# Altium schematic sheet Sheet2.SchDoc
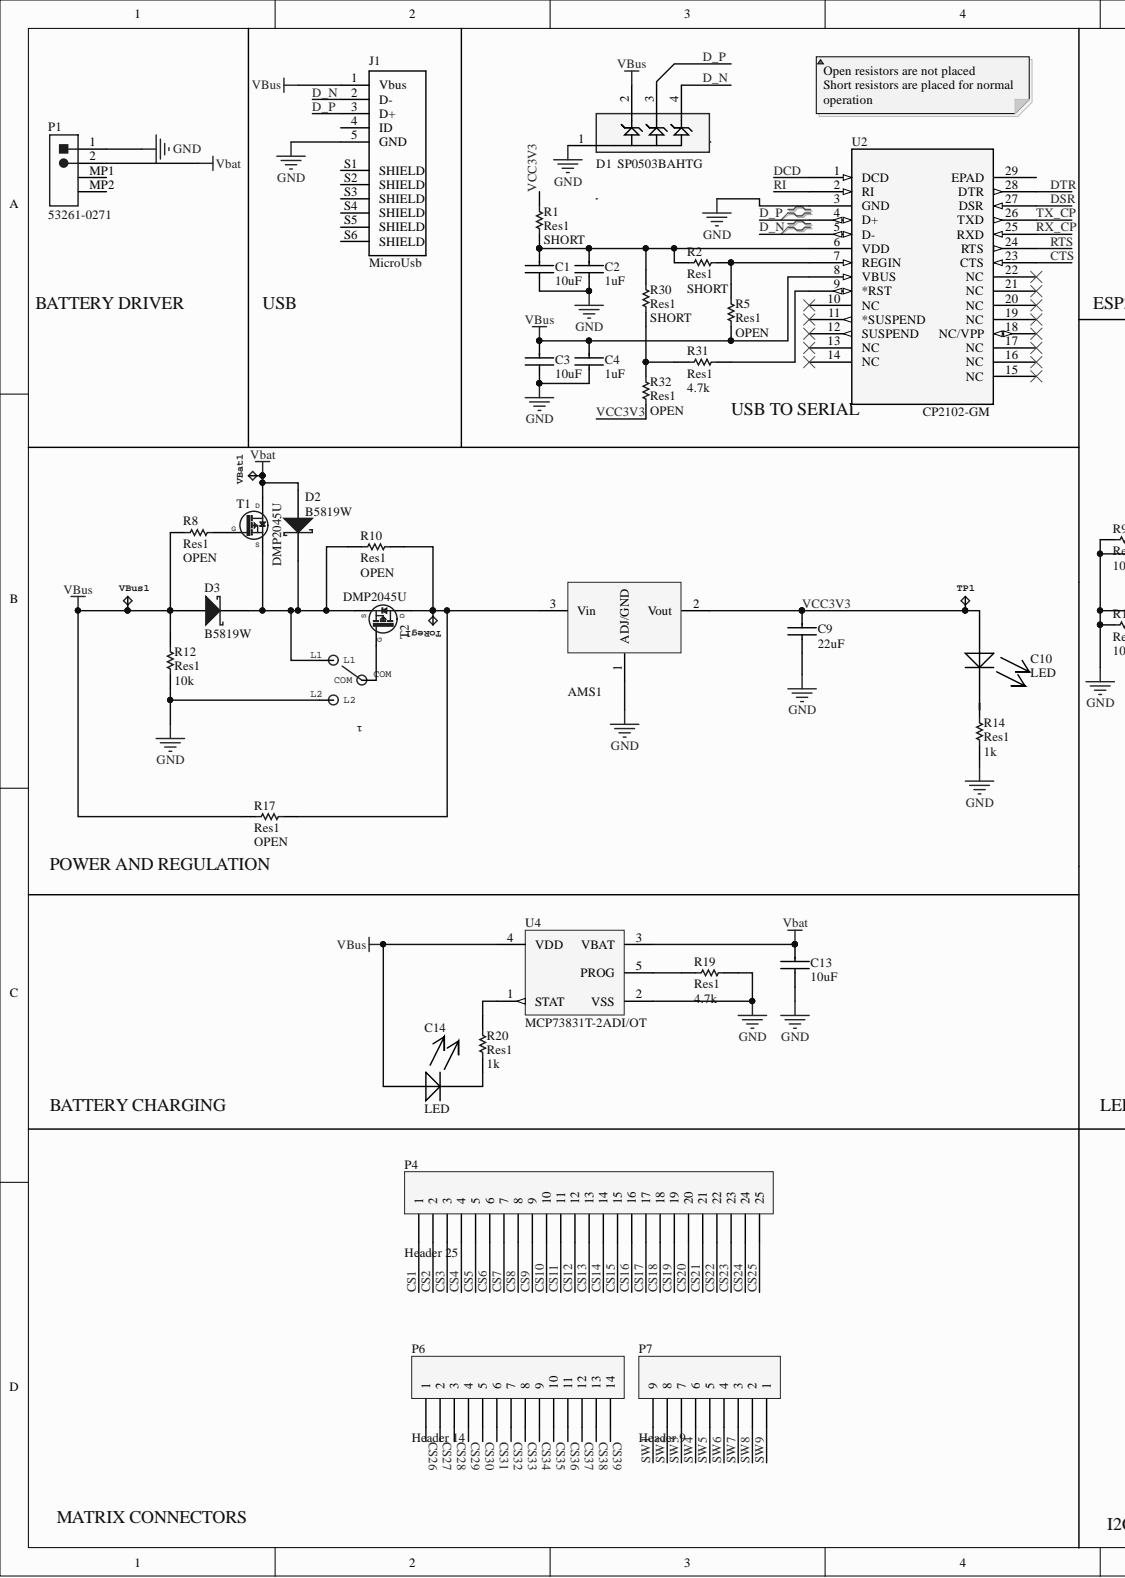
[diagram p0: Sheet2.SchDoc, left]
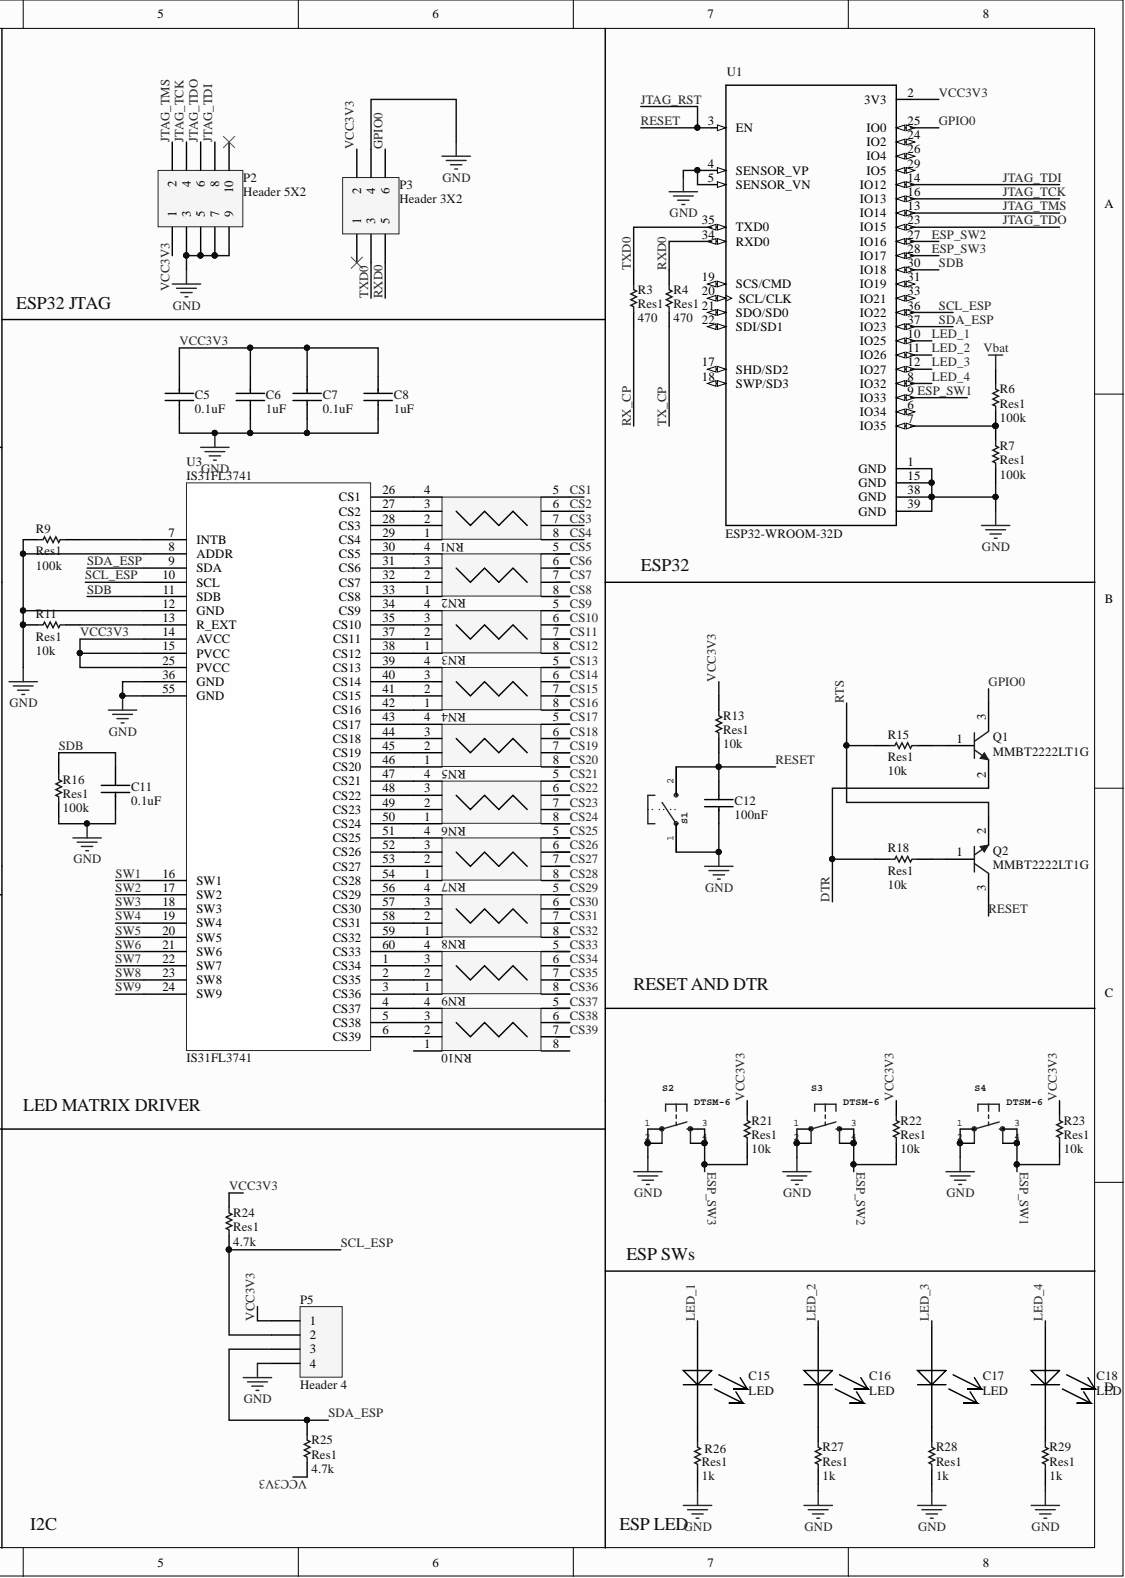
[diagram p1: Sheet2.SchDoc, right]

## Parts
1  Footprint of the VIMEX MSK-12C02 SPDT SMD Switch Created by mzst on 17-Jan-2016  [MSK-12C02]  (value not drawn)
AMS1  AMS1117  [SOT-223-3]  (value not drawn)
C1  10uF  [C 0603 / 1608]
C2  1uF  [C 0603 / 1608]
C3  10uF  [C 0603 / 1608]
C4  1uF  [C 0603 / 1608]
C5  0.1uF  [C 0603 / 1608]
C6  1uF  [C 0603 / 1608]
C7  0.1uF  [C 0603 / 1608]
C8  1uF  [C 0603 / 1608]
C9  22uF  [C 0603 / 1608]
C10  LED  [LED 0603(2)]
C11  0.1uF  [C 0603 / 1608]
C12  100nF  [C 0603 / 1608]
C13  10uF  [C 0603 / 1608]
C14  LED  [LED 0603(2)]
C15  LED  [LED 0603(2)]
C16  LED  [LED 0603(2)]
C17  LED  [LED 0603(2)]
C18  LED  [LED 0603(2)]
D1  Part Number: SP0503BAHTG  [Littelfuse_SP0503BAHTG_0]  (hidden comment: Diode_Littelfuse_SP0503BAHTG_eec)
D2  B5819W  [MCC-SOD123_V]
D3  B5819W  [MCC-SOD123_V]
J1  MicroUsb  [USB-MicroB]
P1  53261-0271  [MOLX-53261-0271_V]
P2  Header 5X2  [5x2_SidePCB]
P3  Header 3X2  [3x2_SidePCB]
P4  Header 25  [HDR1X25]
P5  Header 4  [HDR1X4]
P6  Header 14  [HDR1X14]
P7  Header 9  [HDR1X9]
Q1  MMBT2222LT1G  [ONSC-SOT-23-3-318-08_M]
Q2  MMBT2222LT1G  [ONSC-SOT-23-3-318-08_M]
R1  Res1  value SHORT  [C 0603 / 1608]
R2  Res1  value SHORT  [C 0603 / 1608]
R3  Res1  value 470  [C 0603 / 1608]
R4  Res1  value 470  [C 0603 / 1608]
R5  Res1  value OPEN  [C 0603 / 1608]
R6  Res1  value 100k  [C 0603 / 1608]
R7  Res1  value 100k  [C 0603 / 1608]
R8  Res1  value OPEN  [C 0603 / 1608]
R9  Res1  value 100k  [C 0603 / 1608]
R10  Res1  value OPEN  [C 0603 / 1608]
R11  Res1  value 10k  [C 0603 / 1608]
R12  Res1  value 10k  [C 0603 / 1608]
R13  Res1  value 10k  [C 0603 / 1608]
R14  Res1  value 1k  [C 0603 / 1608]
R15  Res1  value 10k  [C 0603 / 1608]
R16  Res1  value 100k  [C 0603 / 1608]
R17  Res1  value OPEN  [C 0603 / 1608]
R18  Res1  value 10k  [C 0603 / 1608]
R19  Res1  value 4.7k  [C 0603 / 1608]
R20  Res1  value 1k  [C 0603 / 1608]
R21  Res1  value 10k  [C 0603 / 1608]
R22  Res1  value 10k  [C 0603 / 1608]
R23  Res1  value 10k  [C 0603 / 1608]
R24  Res1  value 4.7k  [C 0603 / 1608]
R25  Res1  value 4.7k  [C 0603 / 1608]
R26  Res1  value 1k  [C 0603 / 1608]
R27  Res1  value 1k  [C 0603 / 1608]
R28  Res1  value 1k  [C 0603 / 1608]
R29  Res1  value 1k  [C 0603 / 1608]
R30  Res1  value SHORT  [C 0603 / 1608]
R31  Res1  value 4.7k  [C 0603 / 1608]
R32  Res1  value OPEN  [C 0603 / 1608]
RN1  742C083121JP  [RESARRAY(4x0603)]  (value not drawn)
RN2  742C083121JP  [RESARRAY(4x0603)]  (value not drawn)
RN3  742C083121JP  [RESARRAY(4x0603)]  (value not drawn)
RN4  742C083121JP  [RESARRAY(4x0603)]  (value not drawn)
RN5  742C083121JP  [RESARRAY(4x0603)]  (value not drawn)
RN6  742C083121JP  [RESARRAY(4x0603)]  (value not drawn)
RN7  742C083121JP  [RESARRAY(4x0603)]  (value not drawn)
RN8  742C083121JP  [RESARRAY(4x0603)]  (value not drawn)
RN9  742C083121JP  [RESARRAY(4x0603)]  (value not drawn)
RN10  742C083121JP  [RESARRAY(4x0603)]  (value not drawn)
S1  Switch  [PUSH_SMD_3x4]  (value not drawn)
S2  DTSM-6  [DTSM-6]
S3  DTSM-6  [DTSM-6]
S4  DTSM-6  [DTSM-6]
T1  DMP2045U  [SOT95P237X112-3N]
T2  DMP2045U  [SOT95P237X112-3N]
ToReg1  Test pad  [TP20R]  (value not drawn)
TP1  Test pad  [TP20R]  (value not drawn)
U1  ESP32-WROOM-32D  [MODULE_ESP32-WROOM-32D]
U2  CP2102-GM  [QFN28_5X5]
U3  IS31FL3741  [QFN40P700X700X80-61N]
U4  MCP73831T-2ADI/OT  [SOT-23-OT5_M]
VBat1  Test pad  [TP20R]  (value not drawn)
VBus1  Test pad  [TP20R]  (value not drawn)

## Nets
GND: 1.L2 AMS1.1 C1.1 C2.1 C3.1 C4.1 C5.1 C6.1 C7.1 C8.1 C9.1 C11.2 C12.2 C13.1 D1.1 J1.5(GND) P1.1 P2.3 P2.5 P2.7 P2.9 P3.4 P5.4 R7.1 R9.1 R11.1 R12.2 R14.2 R16.1 R19.1 R26.2 R27.2 R28.2 R29.2 S1.1 S2.1 S2.2 S3.1 S3.2 S4.1 S4.2 U1.1(GND) U1.4(SENSOR_VP) U1.5(SENSOR_VN) U1.15(GND) U1.38(GND) U1.39(GND) U2.3(GND) U3.8(ADDR) U3.12(GND) U3.36(GND) U3.55(GND) U4.2(VSS)
Vbat: C13.2 D2.1 P1.2 R6.2 T1.3 U4.3(VBAT) VBat1.TP
VBus: C3.2 C4.2 C14.1 D1.2 D3.1 J1.1(Vbus) R5.2 R8.1 R12.1 R17.1 U2.8(VBUS) U4.4(VDD) VBus1.TP
CS1: P4.1 RN1.5
CS2: P4.2 RN1.6
CS3: P4.3 RN1.7
CS4: P4.4 RN1.8
CS5: P4.5 RN2.5
CS6: P4.6 RN2.6
CS7: P4.7 RN2.7
CS8: P4.8 RN2.8
CS9: P4.9 RN3.5
CS10: P4.10 RN3.6
CS11: P4.11 RN3.7
CS12: P4.12 RN3.8
CS13: P4.13 RN4.5
CS14: P4.14 RN4.6
CS15: P4.15 RN4.7
CS16: P4.16 RN4.8
CS17: P4.17 RN5.5
CS18: P4.18 RN5.6
CS19: P4.19 RN5.7
CS20: P4.20 RN5.8
CS21: P4.21 RN6.5
CS22: P4.22 RN6.6
CS23: P4.23 RN6.7
CS24: P4.24 RN6.8
CS25: P4.25 RN7.5
CS26: P6.1 RN7.6
CS27: P6.2 RN7.7
CS28: P6.3 RN7.8
CS29: P6.4 RN8.5
CS30: P6.5 RN8.6
CS31: P6.6 RN8.7
CS32: P6.7 RN8.8
CS33: P6.8 RN9.5
CS34: P6.9 RN9.6
CS35: P6.10 RN9.7
CS36: P6.11 RN9.8
CS37: P6.12 RN10.5
CS38: P6.13 RN10.6
CS39: P6.14 RN10.7
CTS: U2.23(CTS)
D_N: D1.4 J1.2(D-) U2.5(D-)
D_P: D1.3 J1.3(D+) U2.4(D+)
DCD: U2.1(DCD)
DSR: U2.27(DSR)
DTR: Q1.2 R18.1 U2.28(DTR)
ESP_SW1: R23.1 S4.3 S4.4 U1.9(IO33)
ESP_SW2: R22.1 S3.3 S3.4 U1.27(IO16)
ESP_SW3: R21.1 S2.3 S2.4 U1.28(IO17)
GPIO0: P3.6 Q1.3 U1.25(IO0)
JTAG_RST: C12.1 Q2.3 R13.2 S1.2 U1.3(EN)
JTAG_TCK: P2.4 U1.16(IO13)
JTAG_TDI: P2.8 U1.14(IO12)
JTAG_TDO: P2.6 U1.23(IO15)
JTAG_TMS: P2.2 U1.13(IO14)
LED_1: C15.1 U1.10(IO25)
LED_2: C16.1 U1.11(IO26)
LED_3: C17.1 U1.12(IO27)
LED_4: C18.1 U1.8(IO32)
RI: U2.2(RI)
RTS: Q2.2 R15.1 U2.24(RTS)
RX_CP: R3.1 U2.25(RXD)
RXD0: P3.5 R4.2 U1.34(RXD0)
SCL_ESP: P5.2 R24.2 U1.36(IO22) U3.10(SCL)
SDA_ESP: P5.3 R25.2 U1.37(IO23) U3.9(SDA)
SDB: C11.1 R16.2 U1.30(IO18) U3.11(SDB)
SW1: P7.9 U3.16(SW1)
SW2: P7.8 U3.17(SW2)
SW3: P7.7 U3.18(SW3)
SW4: P7.6 U3.19(SW4)
SW5: P7.5 U3.20(SW5)
SW6: P7.4 U3.21(SW6)
SW7: P7.3 U3.22(SW7)
SW8: P7.2 U3.23(SW8)
SW9: P7.1 U3.24(SW9)
TX_CP: R4.1 U2.26(TXD)
TXD0: P3.3 R3.2 U1.35(TXD0)
VCC3V3: AMS1.2 C5.2 C6.2 C7.2 C8.2 C9.2 C10.1 P3.2 P5.1 R1.1 R13.1 R21.2 R22.2 R23.2 R24.1 R25.1 R32.1 TP1.TP U1.2(3V3) U3.14(AVCC) U3.15(PVCC) U3.25(PVCC)
Net1_COM: 1.COM T2.1
Net1_L1: 1.L1 D2.2 D3.2 R10.1 T1.2 T2.2
NetAMS1_3: AMS1.3 R10.2 R17.2 T2.3 ToReg1.TP
NetC1_2: C1.2 C2.2 R1.2 R2.1 R30.2 U2.6(VDD)
NetC10_2: C10.2 R14.1
NetC14_2: C14.2 R20.2
NetC15_2: C15.2 R26.1
NetC16_2: C16.2 R27.1
NetC17_2: C17.2 R28.1
NetC18_2: C18.2 R29.1
NetQ1_1: Q1.1 R15.2
NetQ2_1: Q2.1 R18.2
NetR2_2: R2.2 R5.1 U2.7(REGIN)
NetR6_1: R6.1 R7.2 U1.7(IO35)
NetR8_2: R8.2 T1.1
NetR9_2: R9.2 U3.7(INTB)
NetR11_2: R11.2 U3.13(R_EXT)
NetR19_2: R19.2 U4.5(PROG)
NetR20_1: R20.1 U4.1(STAT)
NetR30_1: R30.1 R31.1 R32.2
NetR31_2: R31.2 U2.9(*RST)
NetRN1_1: RN1.1 U3.29(CS4)
NetRN1_2: RN1.2 U3.28(CS3)
NetRN1_3: RN1.3 U3.27(CS2)
NetRN1_4: RN1.4 U3.26(CS1)
NetRN2_1: RN2.1 U3.33(CS8)
NetRN2_2: RN2.2 U3.32(CS7)
NetRN2_3: RN2.3 U3.31(CS6)
NetRN2_4: RN2.4 U3.30(CS5)
NetRN3_1: RN3.1 U3.38(CS12)
NetRN3_2: RN3.2 U3.37(CS11)
NetRN3_3: RN3.3 U3.35(CS10)
NetRN3_4: RN3.4 U3.34(CS9)
NetRN4_1: RN4.1 U3.42(CS16)
NetRN4_2: RN4.2 U3.41(CS15)
NetRN4_3: RN4.3 U3.40(CS14)
NetRN4_4: RN4.4 U3.39(CS13)
NetRN5_1: RN5.1 U3.46(CS20)
NetRN5_2: RN5.2 U3.45(CS19)
NetRN5_3: RN5.3 U3.44(CS18)
NetRN5_4: RN5.4 U3.43(CS17)
NetRN6_1: RN6.1 U3.50(CS24)
NetRN6_2: RN6.2 U3.49(CS23)
NetRN6_3: RN6.3 U3.48(CS22)
NetRN6_4: RN6.4 U3.47(CS21)
NetRN7_1: RN7.1 U3.54(CS28)
NetRN7_2: RN7.2 U3.53(CS27)
NetRN7_3: RN7.3 U3.52(CS26)
NetRN7_4: RN7.4 U3.51(CS25)
NetRN8_1: RN8.1 U3.59(CS32)
NetRN8_2: RN8.2 U3.58(CS31)
NetRN8_3: RN8.3 U3.57(CS30)
NetRN8_4: RN8.4 U3.56(CS29)
NetRN9_1: RN9.1 U3.3(CS36)
NetRN9_2: RN9.2 U3.2(CS35)
NetRN9_3: RN9.3 U3.1(CS34)
NetRN9_4: RN9.4 U3.60(CS33)
NetRN10_2: RN10.2 U3.6(CS39)
NetRN10_3: RN10.3 U3.5(CS38)
NetRN10_4: RN10.4 U3.4(CS37)

Not connected: J1 4(ID) S1(SHIELD) S2(SHIELD) S3(SHIELD) S4(SHIELD) S5(SHIELD) S6(SHIELD); P1 MP1 MP2; P2 1 10; P3 1; RN10 1 8; U1 6(IO34) 17(SHD/SD2) 18(SWP/SD3) 19(SCS/CMD) 20(SCL/CLK) 21(SDO/SD0) 22(SDI/SD1) 24(IO2) 26(IO4) 29(IO5) 31(IO19) 33(IO21); U2 10(NC) 11(*SUSPEND) 12(SUSPEND) 13(NC) 14(NC) 15(NC) 16(NC) 17(NC) 18(NC/VPP) 19(NC) 20(NC) 21(NC) 22(NC) 29(EPAD)

## Library data of the parts
1 = MSK-12C02_SMD_SPDT_SWITCH: Component Kind: Standard; Library Name: Switches.SchLib
AMS1 = AMS1117: LDO 3.3V/5V/12V; Component Kind: Standard; Library Name: IC.SchLib
C1-C9 C11-C13 = Capacitor: Component Kind: Standard; Library Name: Standard.SchLib
D1 = Diode_Littelfuse_SP0503BAHTG_eec: DIODE TVS DIODE 5.5VWM 8.5VC SOT143; Component Kind: Standard; ComponentLink1Description: Upverter; ComponentLink1URL: https://upverter.com/upn/revision/6b5b591e3bf31c16/; ComponentLink2Description: Datasheet; ComponentLink2URL: http://www.littelfuse.com/~/media/electronics/datasheets/tvs_diode_arrays/littelfuse_tvs_diode_array_sp05_datasheet.pdf.pdf; Digikey Description: DIODE TVS DIODE 5.5VWM 8.5VC SOT143; Digikey Part Number: F2715CT-ND; helpURL: https://upverter.com/upn/revision/6b5b591e3bf31c16/; Lead Free: yes; Library Name: IC.SchLib; Manufacturer: Littelfuse; Mouser Part Number: 576-SP0503BAHTG; Package: SOT143-4; Price: 0.571399033067; RoHS: TRUE; Supplier 1: Digi-Key; Supplier 2: Mouser; Supplier Part Number 1: F2715CT-ND; Supplier Part Number 2: 576-SP0503BAHTG; Temperature: -40°C to +85°C; Temperature Range High: +85°C; Temperature Range Low: -40°C
D2 D3 = CMP-2100-03587-1: Schottky Barrier Rectifier, 1 A, 40 Vrm, -65 to 125 degC, 2-Pin SOD123, RoHS, Tape and Reel; Manufacturer: Micro Commercial Components; Component Kind: Standard; Datasheet URL: http://www.mccsemi.com/up_pdf/B5817W-B5819W(SOD-123).pdf; Datasheet Version: Rev. B, 01/2013; Library Name: Altium Content Vault; Manufacturer URL: http://www.mccsemi.com/; Package Description: 2-Pin Small Outline Diode, Body 2.7 x 1.6 mm, Height 1.35 mm; Package Reference: SOD123; Package URL: http://www.mccsemi.com/up_pdf/1N4448W(SOD-123).pdf
J1 = MicroUsb: 10103594-0001LF  Conn Micro USB Type B RCP 5 POS 0.65mm Solder RA SMD 5 Terminal 1 Port T/R; MF: Amphenol ICC; Availability: Unavailable; Component Kind: Standard; Library Name: Connectors.SchLib; MP: 10103594-0001LF; Package: None; Price: None; SnapEDA_Link: https://www.snapeda.com/parts/10103594-0001LF/Amphenol%20ICC/view-part/?ref=snap
P1 = CMP-2000-05633-1: PicoBlade(TM) Header, Right Angle, -40 to 85 degC, 2-Pin SMD, RoHS, Tape and Reel; Brand: Molex; Current - Max: 1A; Number of Positions: 2; Package Version: Rev. H, 11/2014; Pitch: 1.25mm; Voltage - Max: 125V; : 0532610271; Component Kind: Standard; Contact Material: PhosphorBronze; Contact Plating: Tin; Current Rating: 1A; Datasheet URL: http://www.molex.com/webdocs/datasheets/pdf/en-us/0532610271_PCB_HEADERS.pdf; Datasheet Version: 03/2016; Factory Pack Quantity: 1000; Housing Material: Thermoplastic; Library Name: Altium Content Vault; Manufacturer: Molex; Manufacturer URL: http://www.molex.com/; Mounting Angle: Right; Mounting Style: SMD/SMT; Mounting Technology: SurfaceMount; Number of Positions / Contacts: 2; Number of Rows: 1; Package Description: 2-Leads Header, Pitch 1.25 mm, Mated Height 3.4 mm; Package Reference: 53261-0271; Package URL: http://www.molex.com/pdm_docs/sd/532610271_sd.pdf; Packaging: Reel; Product Category: 1.25mmConnectors; Product Type: Headers; RoHS: Details; Series: 53261; Termination Style: SMD; Tradename: PicoBlade; Type: Shrouded; Voltage Rating: 125V
P2 = Header 5X2: Header, 5-Pin, Dual row; Component Kind: Standard; LatestRevisionDate: 17-Jul-2002; LatestRevisionNote: Re-released for DXP Platform.; Library Name: Miscellaneous Connectors.IntLib; Signal Integrity: Connector; Publisher: Altium Limited
P3 = Header 3X2: Header, 3-Pin, Dual row; Component Kind: Standard; LatestRevisionDate: 17-Jul-2002; LatestRevisionNote: Re-released for DXP Platform.; Library Name: Miscellaneous Connectors.IntLib; Signal Integrity: Connector; Publisher: Altium Limited
P4 = Header 25: Header, 25-Pin; Component Kind: Standard; LatestRevisionDate: 17-Jul-2002; LatestRevisionNote: Re-released for DXP Platform.; Library Name: Miscellaneous Connectors.IntLib; Signal Integrity: Connector; Publisher: Altium Limited
P5 = Header 4: Header, 4-Pin; Component Kind: Standard; LatestRevisionDate: 17-Jul-2002; LatestRevisionNote: Re-released for DXP Platform.; Library Name: Miscellaneous Connectors.IntLib; Signal Integrity: Connector; Publisher: Altium Limited
P6 = Header 14: Header, 14-Pin; Component Kind: Standard; LatestRevisionDate: 17-Jul-2002; LatestRevisionNote: Re-released for DXP Platform.; Library Name: Miscellaneous Connectors.IntLib; Signal Integrity: Connector; Publisher: Altium Limited
P7 = Header 9: Header, 9-Pin; Component Kind: Standard; LatestRevisionDate: 17-Jul-2002; LatestRevisionNote: Re-released for DXP Platform.; Library Name: Miscellaneous Connectors.IntLib; Signal Integrity: Connector; Publisher: Altium Limited
Q1 Q2 = CMP-0722-00001-1: General Purpose Transistor, NPN, 0.6 A IC Continuous, 3-Pin SOT23, RoHS, Tape and Reel; Manufacturer: ON Semiconductor; FT: 250 MHz; HFE Max.: 300; HFE Min.: 100; IC Continuous: 0.6 A; PTM Max.: 0.225 W; V(BR) CEO: 30 V Min.; Component Kind: Standard; ComponentLink1Description: Manufacturer URL; ComponentLink1URL: http://www.onsemi.com/; ComponentLink2Description: Datasheet; ComponentLink2URL: http://www.onsemi.com/pub_link/Collateral/MMBT2222LT1-D.PDF; ComponentLink3Description: Package Specification; ComponentLink3URL: http://www.onsemi.com/pub_link/Collateral/MMBT2222LT1-D.PDF; DatasheetVersion: Rev. 10; Library Name: Altium Content Vault; Mounting Technology: Surface Mount; PackageDescription: 3-Pin SOT23, Body 2.9 x 1.3 mm, Pitch 0.95 mm; PackageReference: SOT-23-3; PackageVersion: Rev. AP; Packing: Tape and Reel; PartNumber: MMBT2222LT1G; Polarity: NPN; RoHS: TRUE
R1 R3-R7 R9-R32 = Res1: Resistor; Component Kind: Standard; LatestRevisionDate: 17-Jul-2002; LatestRevisionNote: Re-released for DXP Platform.; Library Name: Miscellaneous Devices.IntLib; PackageDescription: Axial Device, Thru-Hole; 2 Leads; 0.3 in Pin Spacing; PackageReference: AXIAL-0.3; Published: 8-Jun-2000; Signal Integrity: Res; Simulation: RESISTOR; Publisher: Altium Limited
R2 = Res1: Resistor; Value: SHORT; Component Kind: Standard; LatestRevisionDate: 17-Jul-2002; LatestRevisionNote: Re-released for DXP Platform.; Library Name: Miscellaneous Devices.IntLib; PackageDescription: Axial Device, Thru-Hole; 2 Leads; 0.3 in Pin Spacing; PackageReference: AXIAL-0.3; Published: 8-Jun-2000; Signal Integrity: Res; Simulation: RESISTOR; Publisher: Altium Limited
R8 = Res1: Resistor; Value: OPEN; Component Kind: Standard; LatestRevisionDate: 17-Jul-2002; LatestRevisionNote: Re-released for DXP Platform.; Library Name: Miscellaneous Devices.IntLib; PackageDescription: Axial Device, Thru-Hole; 2 Leads; 0.3 in Pin Spacing; PackageReference: AXIAL-0.3; Published: 8-Jun-2000; Signal Integrity: Res; Simulation: RESISTOR; Publisher: Altium Limited
RN1-RN10 = Resistor Array 4x: Res Thick Film Array 120 Ohm 5% 1/4W Â±200ppm/Â°C ISOL Molded 8-Pin 1206_4 X 0603_ Concave SMD Paper T/R; MF: CTS Resistor; Availability: Unavailable; Component Kind: Standard; Library Name: Standard.SchLib; MP: 742C083121JP; Package: 1206 CTS Components; Price: None; SnapEDA_Link: https://www.snapeda.com/parts/742C083121JP/CTS%20Resistor%20Products/view-part/?ref=snap
S1 = B3U-1000P: MANUFACTURER: Omron; Component Kind: Standard; DIGIKEY: SW1020CT-ND; Library Name: Switches.SchLib; MPN: B3U-1000P
T1 T2 = Si2333CDS-T1-GE3: P-CHANNEL MOSFET WITH DIODE; Manufacturer: VISHAY SILICONIX; Part Description: -12V -5.1A P-Channel  TrenchFET® Power MOSFET; Bonding: No; Database Table Name: Transistors; PressFit: No; Case: SOT23-3; ComponentHeight: 1.12mm; Component Kind: Standard; Component Type: Standard; CreateDate: 03/03/2011; Device: Si2333CDS-T1-GE3; HelpURL: \\cern.ch\dfs\Applications\Altium\Datasheets\Si2333CDS-T1-GE3.pdf; LatestRevisionDate: 02/05/2011; Library Name: ICs And Semiconductors.DbLib; Manufacturer Part Number: Si2333CDS-T1-GE3; Mounted: Yes; PackageDescription: SOT, 0.95mm, pitch; 3 pin,1.30mm W X 2.92mm L X 1.12mm H body, IPC Medium Density; Part Number: Si2333CDS-T1-GE3; Pin Count: 3; Sense: No; SMD: Yes; Socket: No; Author: CERN DEM JLC
ToReg1 TP1 VBat1 VBus1 = TPTP20R: Component Kind: Standard; Library Name: Connectors.SchLib
U1 = ESP32-WROOM-32D: IC: SoC; GPIO, I2C, I2S, IR, SD, SDIO, SPI, UART; 2.7Ã·3.6VDC; 20dBm; MF: Espressif Systems; Availability: Warning; Component Kind: Standard; Library Name: Arduino.SchLib; MP: ESP32-WROOM-32D; Package: Module Espressif Systems; Price: 3.98 USD; SnapEDA_Link: https://www.snapeda.com/parts/ESP32-WROOM-32D/Espressif%20Systems/view-part/?ref=snap
U2 = CP2102-GM: No Description Available; Built_By: EMA_UL_Team; Vendor: Silicon Labs; Component Kind: Standard; Library Name: IC.SchLib; Manufacturer_Part_Number: CP2102-GM; RefDes: RefDes; Type: DEV; Value: Value; Copyright: Copyright (C) 2018 Accelerated Designs. All rights reserved
U4 = CMP-0133-00142-1: Miniature Single-Cell, Fully Integrated Li-Ion, Li-Polymer Charge Management Controller, 4.2V, 5-Pin SOT-23, Industrial Temperature, Tape and Reel; Code_IPC: SOT23-5; Component Kind: Standard; DatasheetVersion: revE, Sep-2008; Library Name: Altium Content Vault; PackageDescription: 5-Lead Plastic Small Outline Transistor (OT) [SOT-23]; PackageReference: SOT-23-OT5; PackageVersion: revBB, Aug-2009
C10 C14-C18 = LED: Component Kind: Standard; Library Name: LEDs.SchLib
S2-S4 = DTSM-6: Component Kind: Standard; Library Name: Switches.SchLib
U3 = IS31FL3741: Component Kind: Standard; Library Name: IC.SchLib
